# Altium schematic sheet Schematic.SchDoc
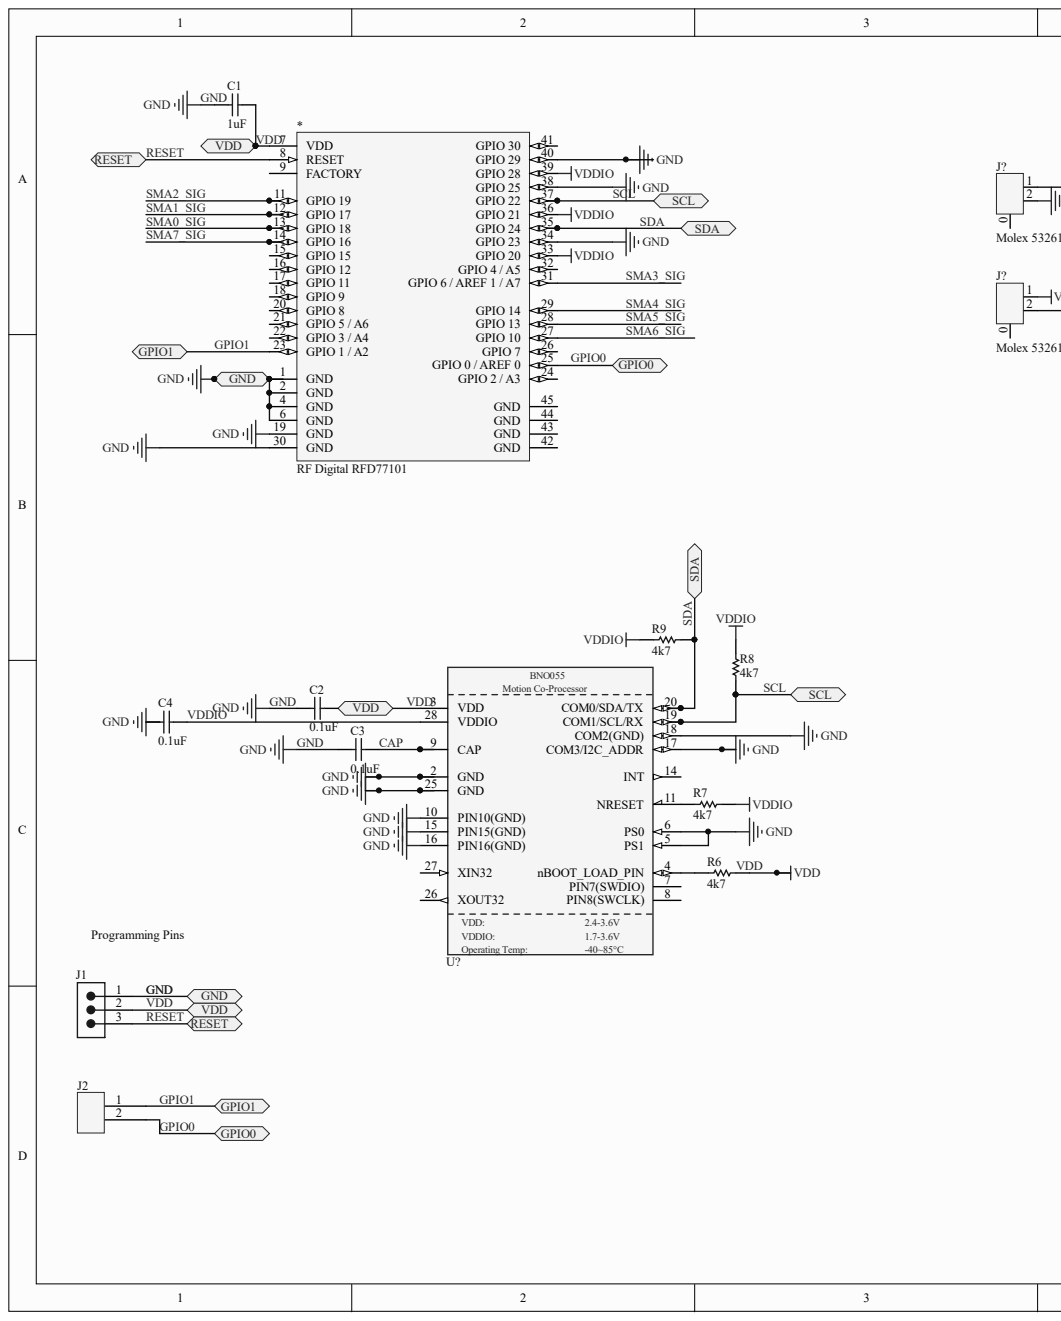
[diagram p0: Schematic.SchDoc, left]
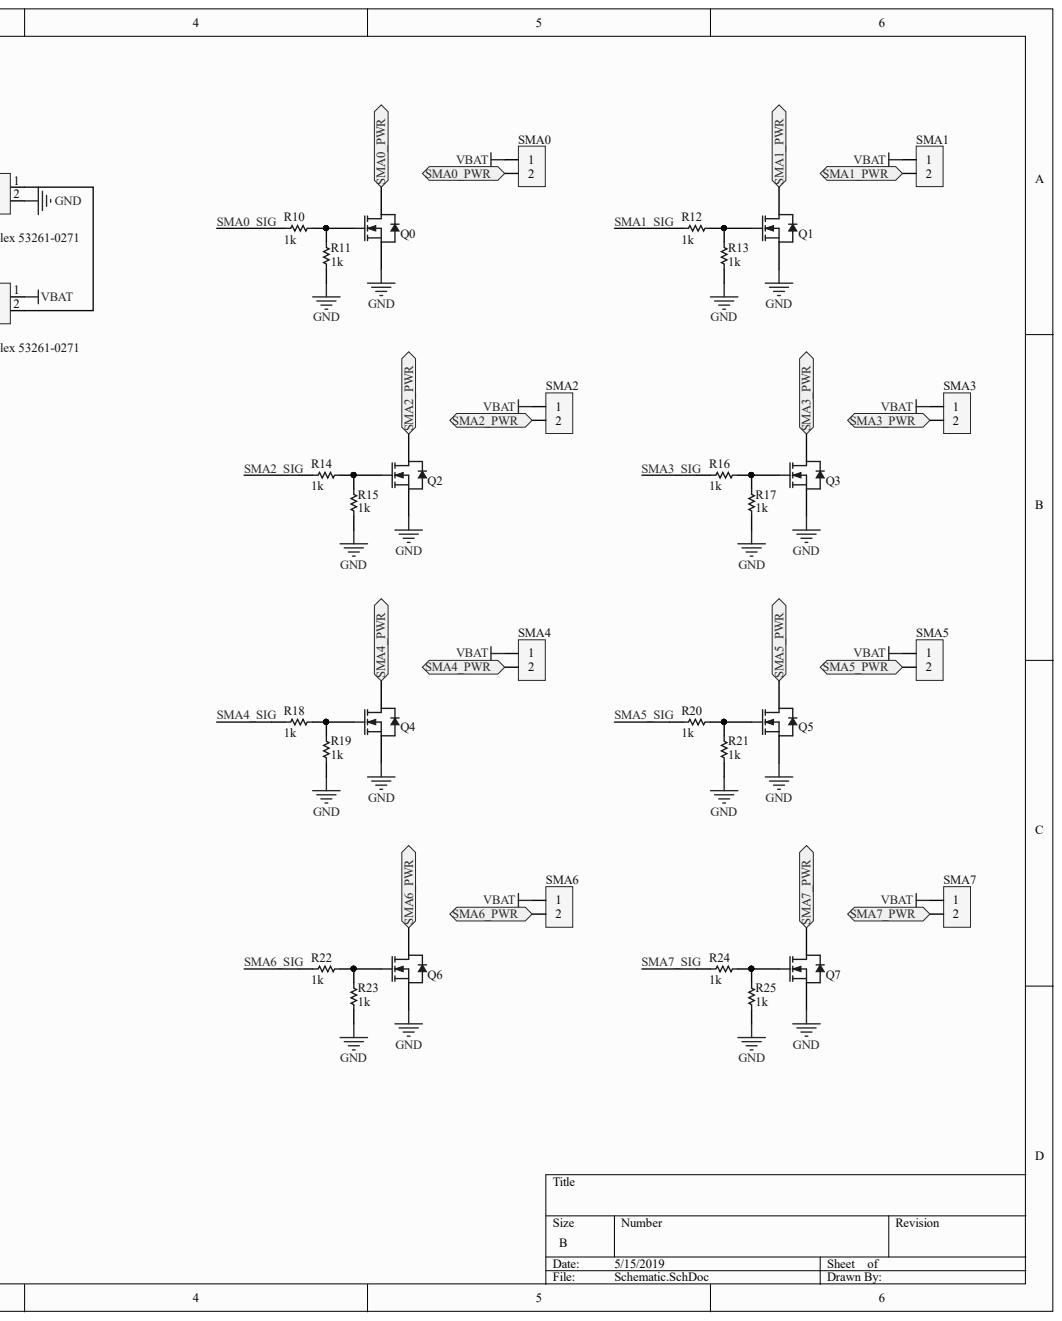
[diagram p1: Schematic.SchDoc, right]

## Parts
*  RF Digital RFD77101  Manufacturer Part Number: RFD77101  [PCB-7ciq19fmj8mf7u1kslem-1]
C1  1uF  [PCB-8tuutlkq7p1aj3t2dfdp-1]
C2  0.1uF  [PCB-8tuutlkq7p1aj3t2dfdp-1]
C3  0.1uF  [PCB-8tuutlkq7p1aj3t2dfdp-1]
C4  0.1uF  [PCB-8tuutlkq7p1aj3t2dfdp-1]
J1  Samtec TSW-103-07-G-S  [SMTC-TSW-103-07-X-S]  (value not drawn)
J2  Samtec TSW-102-07-G-S  [PCB-ywuyzljsefp2psq2zqh0-1]  (value not drawn)
J?  Molex 53261-0271  [PCB-3jiuxcj61nfa3u5b4ed9-1]
J?  Molex 53261-0271  [PCB-3jiuxcj61nfa3u5b4ed9-1]
Q0  Alpha & Omega Semiconductor AO3416  [MF03A_L]  (value not drawn)
Q1  Alpha & Omega Semiconductor AO3416  [MF03A_L]  (value not drawn)
Q2  Alpha & Omega Semiconductor AO3416  [MF03A_L]  (value not drawn)
Q3  Alpha & Omega Semiconductor AO3416  [MF03A_L]  (value not drawn)
Q4  Alpha & Omega Semiconductor AO3416  [MF03A_L]  (value not drawn)
Q5  Alpha & Omega Semiconductor AO3416  [MF03A_L]  (value not drawn)
Q6  Alpha & Omega Semiconductor AO3416  [MF03A_L]  (value not drawn)
Q7  Alpha & Omega Semiconductor AO3416  [MF03A_L]  (value not drawn)
R6  4k7  [RESC1005X40X25NL10T10]
R7  4k7  [RESC1005X40X25NL10T10]
R8  4k7  [RESC1005X40X25NL10T10]
R9  4k7  [RESC1005X40X25NL10T10]
R10  1k  [RESC1005X40X25ML10T10]
R11  1k  [RESC1005X40X25ML10T10]
R12  1k  [RESC1005X40X25ML10T10]
R13  1k  [RESC1005X40X25ML10T10]
R14  1k  [RESC1005X40X25ML10T10]
R15  1k  [RESC1005X40X25ML10T10]
R16  1k  [RESC1005X40X25ML10T10]
R17  1k  [RESC1005X40X25ML10T10]
R18  1k  [RESC1005X40X25ML10T10]
R19  1k  [RESC1005X40X25ML10T10]
R20  1k  [RESC1005X40X25ML10T10]
R21  1k  [RESC1005X40X25ML10T10]
R22  1k  [RESC1005X40X25ML10T10]
R23  1k  [RESC1005X40X25ML10T10]
R24  1k  [RESC1005X40X25ML10T10]
R25  1k  [RESC1005X40X25ML10T10]
SMA0  Samtec TSW-102-07-G-S  [PCB-ywuyzljsefp2psq2zqh0-1]  (value not drawn)
SMA1  Samtec TSW-102-07-G-S  [PCB-ywuyzljsefp2psq2zqh0-1]  (value not drawn)
SMA2  Samtec TSW-102-07-G-S  [PCB-ywuyzljsefp2psq2zqh0-1]  (value not drawn)
SMA3  Samtec TSW-102-07-G-S  [PCB-ywuyzljsefp2psq2zqh0-1]  (value not drawn)
SMA4  Samtec TSW-102-07-G-S  [PCB-ywuyzljsefp2psq2zqh0-1]  (value not drawn)
SMA5  Samtec TSW-102-07-G-S  [PCB-ywuyzljsefp2psq2zqh0-1]  (value not drawn)
SMA6  Samtec TSW-102-07-G-S  [PCB-ywuyzljsefp2psq2zqh0-1]  (value not drawn)
SMA7  Samtec TSW-102-07-G-S  [PCB-ywuyzljsefp2psq2zqh0-1]  (value not drawn)
U?  Bosch Tools BNO055  [LGA28C50P10X6_520X380X118]  (value not drawn)

## Ports
GND, GPIO0, GPIO1, RESET, SCL, SDA, SMA0_PWR, SMA1_PWR, SMA2_PWR, SMA3_PWR, SMA4_PWR, SMA5_PWR, SMA6_PWR, SMA7_PWR, VDD

## Nets
VBAT: J?.1 SMA0.1 SMA1.1 SMA2.1 SMA3.1 SMA4.1 SMA5.1 SMA6.1 SMA7.1
CAP: C3.2 U?.9(CAP)
GND: *.1(GND) *.2(GND) *.4(GND) *.6(GND) *.19(GND) *.30(GND) *.34(GPIO_23) *.38(GPIO_25) *.40(GPIO_29) C1.1 C2.1 C3.1 C4.1 J1.1 J?.2 Q0.2 Q1.2 Q2.2 Q3.2 Q4.2 Q5.2 Q6.2 Q7.2 R11.1 R13.1 R15.1 R17.1 R19.1 R21.1 R23.1 R25.1 U?.2(GND) U?.5(PS1) U?.6(PS0) U?.10(PIN10(GND)) U?.15(PIN15(GND)) U?.16(PIN16(GND)) U?.17(COM3/I2C_ADDR) U?.18(COM2(GND)) U?.25(GND) ; port GND
GPIO0: *.25(GPIO_0_/_AREF_0) J2.2 ; port GPIO0
GPIO1: *.23(GPIO_1_/_A2) J2.1 ; port GPIO1
RESET: *.8(RESET) J1.3 ; port RESET
SCL: *.37(GPIO_22) R8.1 U?.19(COM1/SCL/RX) ; port SCL
SDA: *.35(GPIO_24) R9.1 U?.20(COM0/SDA/TX) ; port SDA
SMA0_PWR: Q0.3 SMA0.2 ; port SMA0_PWR
SMA0_SIG: *.13(GPIO_18) R10.1
SMA1_PWR: Q1.3 SMA1.2 ; port SMA1_PWR
SMA1_SIG: *.12(GPIO_17) R12.1
SMA2_PWR: Q2.3 SMA2.2 ; port SMA2_PWR
SMA2_SIG: *.11(GPIO_19) R14.1
SMA3_PWR: Q3.3 SMA3.2 ; port SMA3_PWR
SMA3_SIG: *.31(GPIO_6_/_AREF_1_/_A7) R16.1
SMA4_PWR: Q4.3 SMA4.2 ; port SMA4_PWR
SMA4_SIG: *.29(GPIO_14) R18.1
SMA5_PWR: Q5.3 SMA5.2 ; port SMA5_PWR
SMA5_SIG: *.28(GPIO_13) R20.1
SMA6_PWR: Q6.3 SMA6.2 ; port SMA6_PWR
SMA6_SIG: *.27(GPIO_10) R22.1
SMA7_PWR: Q7.3 SMA7.2 ; port SMA7_PWR
SMA7_SIG: *.14(GPIO_16) R24.1
VDD: *.7(VDD) C1.2 C2.2 J1.2 R6.2 U?.3(VDD) ; port VDD
VDDIO: *.33(GPIO_20) *.36(GPIO_21) *.39(GPIO_28) C4.2 R7.2 R8.2 R9.2 U?.28(VDDIO)
NetJ?_1: J?.1 J?.2
NetQ0_1: Q0.1 R10.2 R11.2
NetQ1_1: Q1.1 R12.2 R13.2
NetQ2_1: Q2.1 R14.2 R15.2
NetQ3_1: Q3.1 R16.2 R17.2
NetQ4_1: Q4.1 R18.2 R19.2
NetQ5_1: Q5.1 R20.2 R21.2
NetQ6_1: Q6.1 R22.2 R23.2
NetQ7_1: Q7.1 R24.2 R25.2
NetR6_1: R6.1 U?.4(nBOOT_LOAD_PIN)
NetR7_1: R7.1 U?.11(NRESET)

Not connected: * 9(FACTORY) 15(GPIO_15) 16(GPIO_12) 17(GPIO_11) 18(GPIO_9) 20(GPIO_8) 21(GPIO_5_/_A6) 22(GPIO_3_/_A4) 24(GPIO_2_/_A3) 26(GPIO_7) 32(GPIO_4_/_A5) 41(GPIO_30) 42(GND) 43(GND) 44(GND) 45(GND); J? 0; U? 7(PIN7(SWDIO)) 8(PIN8(SWCLK)) 14(INT) 26(XOUT32) 27(XIN32)

## Library data of the parts
* = CMP-1631dc5cc862c9f6-6: Simblee Smt Module; Component Kind: Standard; Library Name: CommunityVault
C1-C4 = CMP-a18e680969d57e49-3: CL05 Series 1 uF 16 V ±10 % Tolerance X5R SMT Multilayer Ceramic Capacitor; Manufacturer Part Number: CL05A105KO5NNNC; Capacitance: 1.00 µF; Capacitance Tolerance: ±10%; Lead-Free Status: Lead Free; Voltage Rating (DC): 16.0 V; Case/Package: 0402; Component Kind: Standard; Dielectric Characteristic: X5R; Library Name: CommunityVault; Mounting Style: Surface Mount; OctopartID: a18e680969d57e49; Octopart URL: http://octopart.com/cl05a105ko5nnnc-samsung-9301309; RoHS: Compliant
J1 = CMP-3080438-4: Conn Unshrouded Header HDR 3 POS 2.54mm Solder ST Thru-Hole; Gender: Male; Lead-Free Status: Lead Free; Number of Contacts: 3; Pin Pitch: 0.00254 m; Component Kind: Standard; Contact Material: Phosphor Bronze; Contact Plating: Gold; Housing Color: Black; Housing Material: Plastic; Library Name: CommunityVault; Mounting Style: Through Hole; Number of Positions: 3; Number of Rows: 1; OctopartID: eace23c0e8ee3509; Octopart Url: https://octopart.com/tsw-103-07-g-s-samtec-272198; REACH SVHC Compliance: No SVHC; RoHS: Compliant; Size-Height: 0.00254 m; Size-Length: 0.00762 m; Size-Width: 0.00254 m
J2 = CMP-660195-5: Conn Unshrouded Header HDR 2 POS 2.54mm Solder ST Thru-Hole Automotive Bulk; Gender: Male; Lead-Free Status: Lead Free; Number of Contacts: 2; Component Kind: Standard; Contact Material: Phosphor Bronze; Contact Plating: Gold; Housing Color: Black; Housing Material: Plastic; Library Name: CommunityVault; Manufacturer Part Number: TSW-102-07-G-S; Mounting Style: Through Hole; Number of Positions: 2; Pin Pitch: 2.54 mm; REACH SVHC Compliance: No SVHC; RoHS: Compliant; Size-Height: 2.54 mm; Size-Length: 5.08 mm; Size-Width: 2.54 mm
J? J? = 53261-0271: Conn Shrouded Header HDR 2 POS 1.25mm Solder RA SMD PicoBlade™ Embossed T/R; Current Rating: 5.50 A; Gender: Male; Glow Wire Compliant: Non-Compliant; Insulation Resistance: 100 MΩ; Lead-Free Status: Lead Free; Number of Circuits: 2; Voltage Rating (AC): 125 V; Color: Beige; Component Kind: Standard; Contact Material: Phosphor Bronze; Contact Plating: Tin; Flammability Rating: UL 94 V-0; Halogen Free Status: Not Low Halogen; Housing Material: Nylon; Library Name: Octopart library; Lifecycle Status: Active; Manufacturer Part Number: 53261-0271; Mounting Style: Surface Mount; Number of Contacts: 2; Number of Positions: 2; Number of Rows: 1; OctopartID: 7c69b56aa6cea3ae; Octopart URL: http://octopart.com/53261-0271-molex-655297; Orientation: Right Angle; Packaging: Reel, Cut Tape (CT); Pin Pitch: 1.25 mm; REACH SVHC Compliance: No SVHC; RoHS: Compliant; Size-Height: 3.40 mm; Size-Length: 7.65 mm; Size-Width: 4.20 mm; Voltage Rating (DC): 125 V
Q0-Q7 = CMP-4153047-3: Trans MOSFET N-CH 20V 6.5A 3-Pin SOT-23; Component Kind: Standard; Library Name: CommunityVault; Manufacturer Part Number: AO3416; Maximum Current: 6.5A; Maximum Voltage - Drain: 20V; OctopartID: 85974c2052c45a50; Octopart URL: http://octopart.com/ao3416-alpha+%26+omega+semiconductor-12448309; RoHS: Compliant
R6-R9 = CMP-1850649-2: Res Thin Film 0402 4.7K Ohm 0.5% 1/16W ±25ppm/C Molded SMD Punched Carrier T/R; Manufacturer Part Number: ERA-2AED472X; Lead-Free Status: Lead Free; Power Rating: 0.063 W; Resistance: 4700.0 Ω; Voltage Rating (DC): 25.0 V; Case/Package: 0402; Component Kind: Standard; Library Name: CommunityVault; Lifecycle Status: Not Listed by Manufacturer; OctopartID: 4b2780b9167794e0; Octopart Url: https://octopart.com/era-2aed472x-panasonic-43284534; Packaging: Cut Tape (CT); REACH SVHC Compliance: No SVHC; Resistance Tolerance: ±0.5%; RoHS: Compliant
R10-R25 = CMP-1850622-4: Res Thin Film 0402 1K Ohm 0.5% 0.063W(1/16W) ±25ppm/C Molded SMD Automotive Punched T/R; Component Kind: Standard; Library Name: CommunityVault
SMA0-SMA7 = CMP-660195-6: Conn Unshrouded Header HDR 2 POS 2.54mm Solder ST Thru-Hole Automotive Bulk; Component Kind: Standard; Library Name: CommunityVault
U? = CMP-4c048708187993c3-12: BNO055 Series 1.7 to 3.6 V Intelligent 9-Axis Absolute Orientation Sensor-LGA-28; Lead-Free Status: Lead Free; Component Kind: Standard; Library Name: CommunityVault; OctopartID: 4c048708187993c3; Octopart URL: https://octopart.com/bno055-bosch+tools-33976603; RoHS: Compliant
